# Altium schematic sheet nRF9160.SchDoc
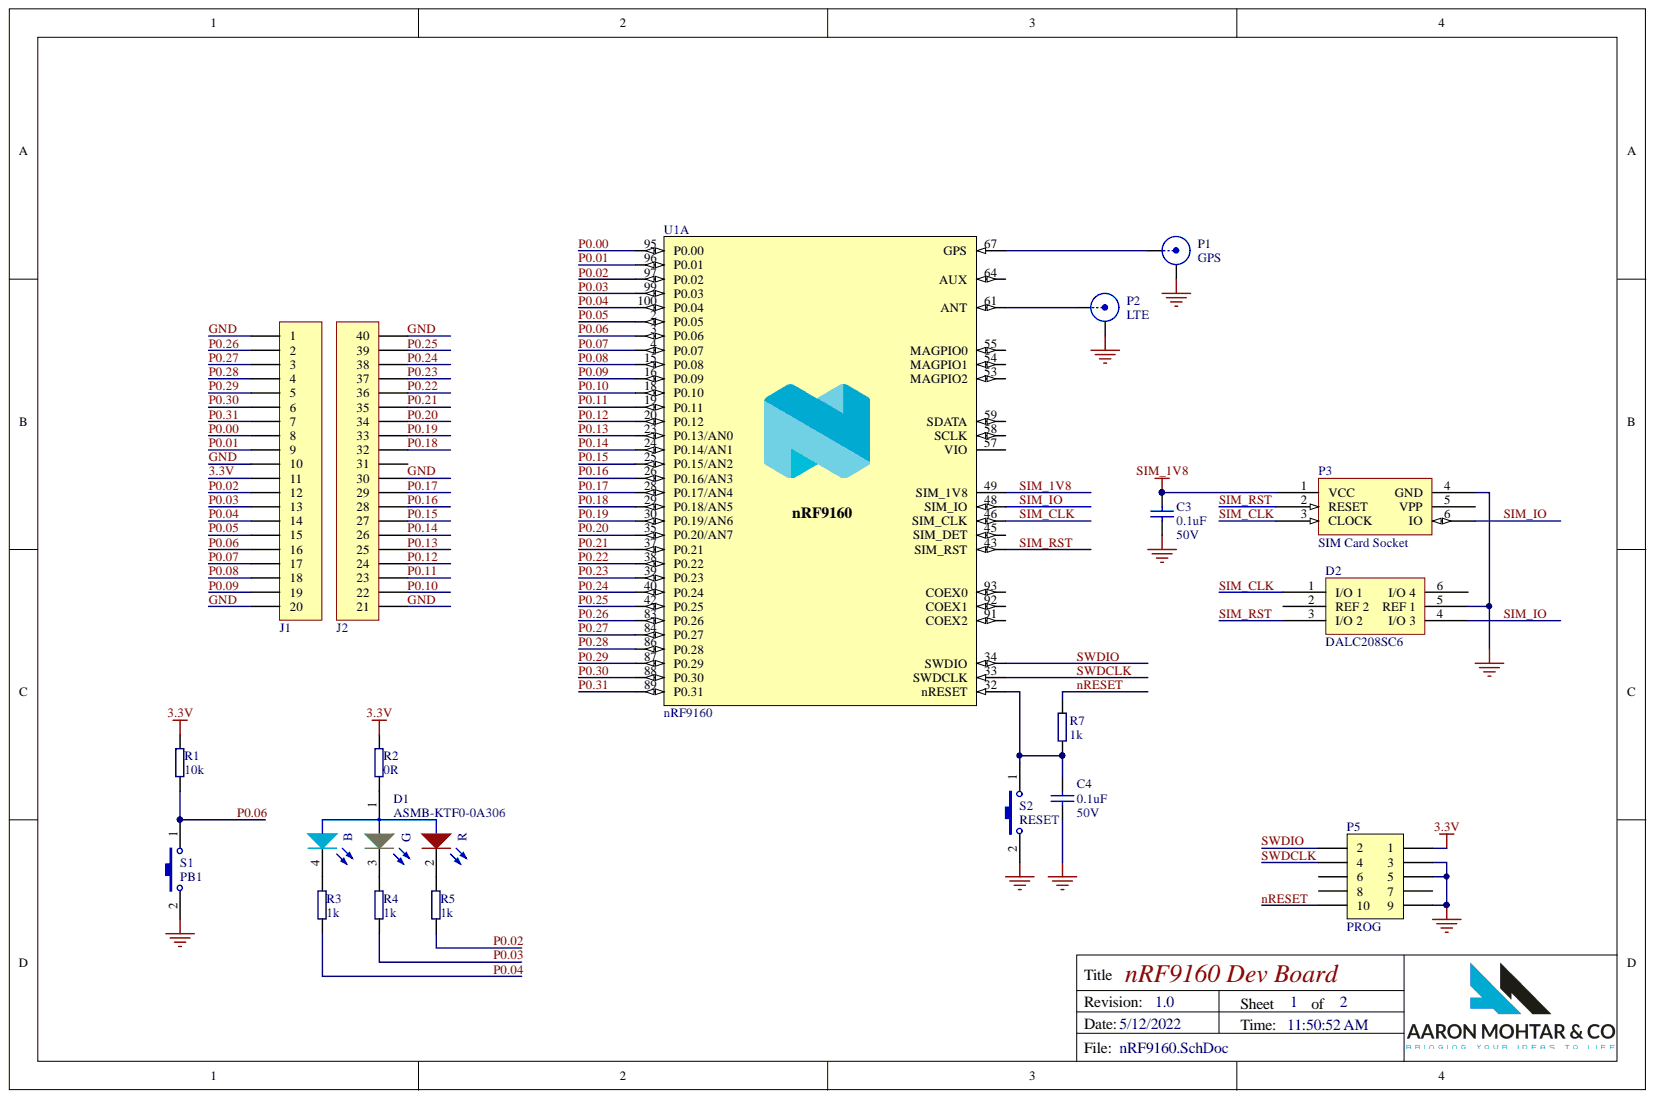
[diagram p0: nRF9160.SchDoc]

## Parts
C3  value 0.1uF  Voltage: 50V  [CAPC0603(1608)100_N]  (hidden comment: 0.1uF 0603 50V)
C4  value 0.1uF  Voltage: 50V  [CAPC0603(1608)100_N]  (hidden comment: 0.1uF 0603 50V)
D1  ASMB-KTF0-0A306  [FP-PLCC-4-MFG]
D2  DALC208SC6  [FP-SOT23-6L-MFG]
J1  HDR 2.54 VERT SIL 20POS  [M20-9992045]  (value not drawn)
J2  HDR 2.54 VERT SIL 20POS (B)  [M20-9992045-(B)]  (value not drawn)
P1  GPS  [FP-U_FL-R-SMT_10-MFG]
P2  LTE  [FP-U_FL-R-SMT_10-MFG]
P3  SIM Card Socket  [1042240820]
P5  PROG  [HDR_2x5-SMD-1.27mm]
R1  10k  [RESC0603(1608)_N]
R2  0R  [RESC0603(1608)_N]
R3  1k  [RESC0603(1608)_N]
R4  1k  [RESC0603(1608)_N]
R5  1k  [RESC0603(1608)_N]
R7  value 1k  [RESC0603(1608)_N]  (hidden comment: 1K 0603)
S1  PB1  [KMR231NGLFS]
S2  RESET  [KMR231NGLFS]
U1  nRF9160  [LGA50P1600X1050X104-161N]  (part A)

## Nets
3.3V: J1.11 P5.1 R1.2 R2.2
GND: C3.1 C4.1 D2.5(REF_1) J1.1 J1.10 J1.20 J2.21 J2.30 J2.40 P1.2 P2.2 P3.4(GND) P3.7 P3.8 P3.9 P3.10 P5.3 P5.5 P5.9 S1.2 S2.2
NRESET: P5.10 R7.2
P0.00: J1.8 U1.95(P0.00)
P0.01: J1.9 U1.96(P0.01)
P0.02: J1.12 R5.1 U1.97(P0.02)
P0.03: J1.13 R4.1 U1.99(P0.03)
P0.04: J1.14 R3.1 U1.100(P0.04)
P0.05: J1.15 U1.2(P0.05)
P0.06: J1.16 R1.1 S1.1 U1.3(P0.06)
P0.07: J1.17 U1.4(P0.07)
P0.08: J1.18 U1.15(P0.08)
P0.09: J1.19 U1.16(P0.09)
P0.10: J2.22 U1.18(P0.10)
P0.11: J2.23 U1.19(P0.11)
P0.12: J2.24 U1.20(P0.12)
P0.13: J2.25 U1.23(P0.13/AN0)
P0.14: J2.26 U1.24(P0.14/AN1)
P0.15: J2.27 U1.25(P0.15/AN2)
P0.16: J2.28 U1.26(P0.16/AN3)
P0.17: J2.29 U1.28(P0.17/AN4)
P0.18: J2.32 U1.29(P0.18/AN5)
P0.19: J2.33 U1.30(P0.19/AN6)
P0.20: J2.34 U1.35(P0.20/AN7)
P0.21: J2.35 U1.37(P0.21)
P0.22: J2.36 U1.38(P0.22)
P0.23: J2.37 U1.39(P0.23)
P0.24: J2.38 U1.40(P0.24)
P0.25: J2.39 U1.42(P0.25)
P0.26: J1.2 U1.83(P0.26)
P0.27: J1.3 U1.84(P0.27)
P0.28: J1.4 U1.86(P0.28)
P0.29: J1.5 U1.87(P0.29)
P0.30: J1.6 U1.88(P0.30)
P0.31: J1.7 U1.89(P0.31)
SIM_1V8: C3.2 P3.1(VCC) U1.49(SIM_1V8)
SIM_CLK: D2.1(I/O_1) P3.3(CLOCK) U1.46(SIM_CLK)
SIM_IO: D2.4(I/O_3) P3.6(IO) U1.48(SIM_IO)
SIM_RST: D2.3(I/O_2) P3.2(RESET) U1.43(SIM_RST)
SWDCLK: P5.4 U1.33(SWDCLK)
SWDIO: P5.2 U1.34(SWDIO)
NetC4_2: C4.2 R7.1 S2.1 U1.32(nRESET)
NetD1_1: D1.1 R2.1
NetD1_2: D1.2 R5.2
NetD1_3: D1.3 R4.2
NetD1_4: D1.4 R3.2
NetP1_1: P1.1 U1.67(GPS)
NetP2_1: P2.1 U1.61(ANT)

Not connected: D2 2(REF_2) 6(I/O_4); J2 31; P3 5(VPP); P5 6 7 8; U1 45(SIM_DET) 53(MAGPIO2) 54(MAGPIO1) 55(MAGPIO0) 57(VIO) 58(SCLK) 59(SDATA) 64(AUX) 91(COEX2) 92(COEX1) 93(COEX0)

## Library data of the parts
C3 C4 = CAP 0.1uF 25V 0603: CAP CER 0.1UF 50V X7R 0603; Manufacturer: AVX Corporation; PartNumber: 06035C104KAT2A; DK code: 478-5052-1-ND; Component Kind: Standard; Operating temperature: -55°C ~ 125°C; PackageDescription: 0603; Temperature coefficient: X7R; Library Name: TranspIR.SchLib
D1 = ASMB-KTF0-0A306: LED RGB 4PLCC SMD; Manufacturer: Broadcom Limited; DK code: 516-3906-1-ND; Component Kind: Standard; Library Name: Discretes.SchLib; PackageDescription: 4PLCC; PartNumber: ASMB-KTF0-0A306
D2 = DALC208SC6: TVS Diode 5V SOT23-6; Manufacturer: STMicroelectronics; DK code: 497-2525-1-ND; Component Kind: Standard; PackageDescription: SOT23-6; PartNumber: DALC208SC6; Library Name: ufg-cherrp-schematicLibrary.SchLib
J1 = HDR 2.54 VERT SIL 20POS: CONN HEADER VERT 20POS 2.54MM; Manufacturer: Harwin Inc.; PartNumber: M20-9992046; DK code: 952-3306-ND; Component Kind: Standard; Library Name: Connectors.SchLib
J2 = HDR 2.54 VERT SIL 20POS (B): CONN HEADER VERT 20POS 2.54MM; Manufacturer: Harwin Inc.; PartNumber: M20-9992046; DK code: 952-3306-ND; Component Kind: Standard; Library Name: Connectors.SchLib
P1 P2 = U.FL-R-SMT: CONN U.FL RCPT STR 50 OHM SMD; Manufacturer: Hirose Electric Co Ltd; PartNumber: U.FL-R-SMT(10); DK code: H9161CT-ND; Component Kind: Standard; Library Name: TranspIR.SchLib
P3 = SIM Card Connector: SIM Card Connector (Nano); Manufacturer: Molex; DK Code: WM23749CT-ND; Component Kind: Standard; PartNumber: 1042240820; Library Name: ufg-cherrp-schematicLibrary.SchLib
P5 = CONN 2X5 1.27mm - HARWIN: CONN HEADER SMD 10POS 1.27MM; Manufacturer: Harwin Inc.; PartNumber: M50-3600542; DK code: 952-1389-ND; Component Kind: Standard; PackageDescription: 10POS 1.27mm; Library Name: TranspIR.SchLib
R1 = RES 10K 0603: RES SMD 10K OHM 1% 1/10W 0603; Manufacturer: Yageo; PartNumber: RC0603FR-0710KL; DK code: 311-10.0KHRCT-ND; Component Kind: Standard; Library Name: Passives.SchLib; PackageDescription: 0603; Tolerance: 1%; Value: 10k
R2 = RES 0 0603: RES SMD 0 OHM 1% 1/10W 0603; Manufacturer: Yageo; PartNumber: RC0603JR-070RL; DK code: 311-0.0GRCT-ND; Tolerance: 5%; Component Kind: Standard; Library Name: Passives.SchLib; PackageDescription: 0603; Value: 0R
R3-R5 = RES 1K 0603: RES SMD 1K OHM 1% 1/10W 0603; Manufacturer: Yageo; PartNumber: RC0603FR-071KL; DK code: 311-1.00KHRCT-ND; Component Kind: Standard; Library Name: Passives.SchLib; PackageDescription: 0603; Tolerance: 1%; Value: 1k
R7 = RES 1K 0603: RES 1K OHM 1% 1/10W 0603; Manufacturer: Stackpole Electronics Inc; PartNumber: RMCF0603FT1K00; DK code: RMCF0603FT1K00CT-ND; Component Kind: Standard; PackageDescription: 0603; Tolerance: 1%; Library Name: TranspIR.SchLib
S1 S2 = KMR231NGLFS: SWITCH TACTILE SPST-NO 0.05A 32V; Manufacturer: C&K; DK code: CKN10246CT-ND; Component Kind: Standard; Library Name: Miscellaneous.SchLib; PartNumber: KMR231NGLFS
U1 = nRF9160_SICA_1: Low power System-in-Package with integrated LTE-M/NB-IoT modem and GPS; Manufacturer: Nordic Semiconductor; PartNumber: NRF9160-SICA-B1A-R; DK code: 1490-NRF9160-SICA-B1A-RCT-ND; Component Kind: Standard; PackageDescription: 161 TFLGA; Library Name: TranspIR.SchLib
